# Altium schematic sheet StudentForce_DYNAMIC.SchDoc
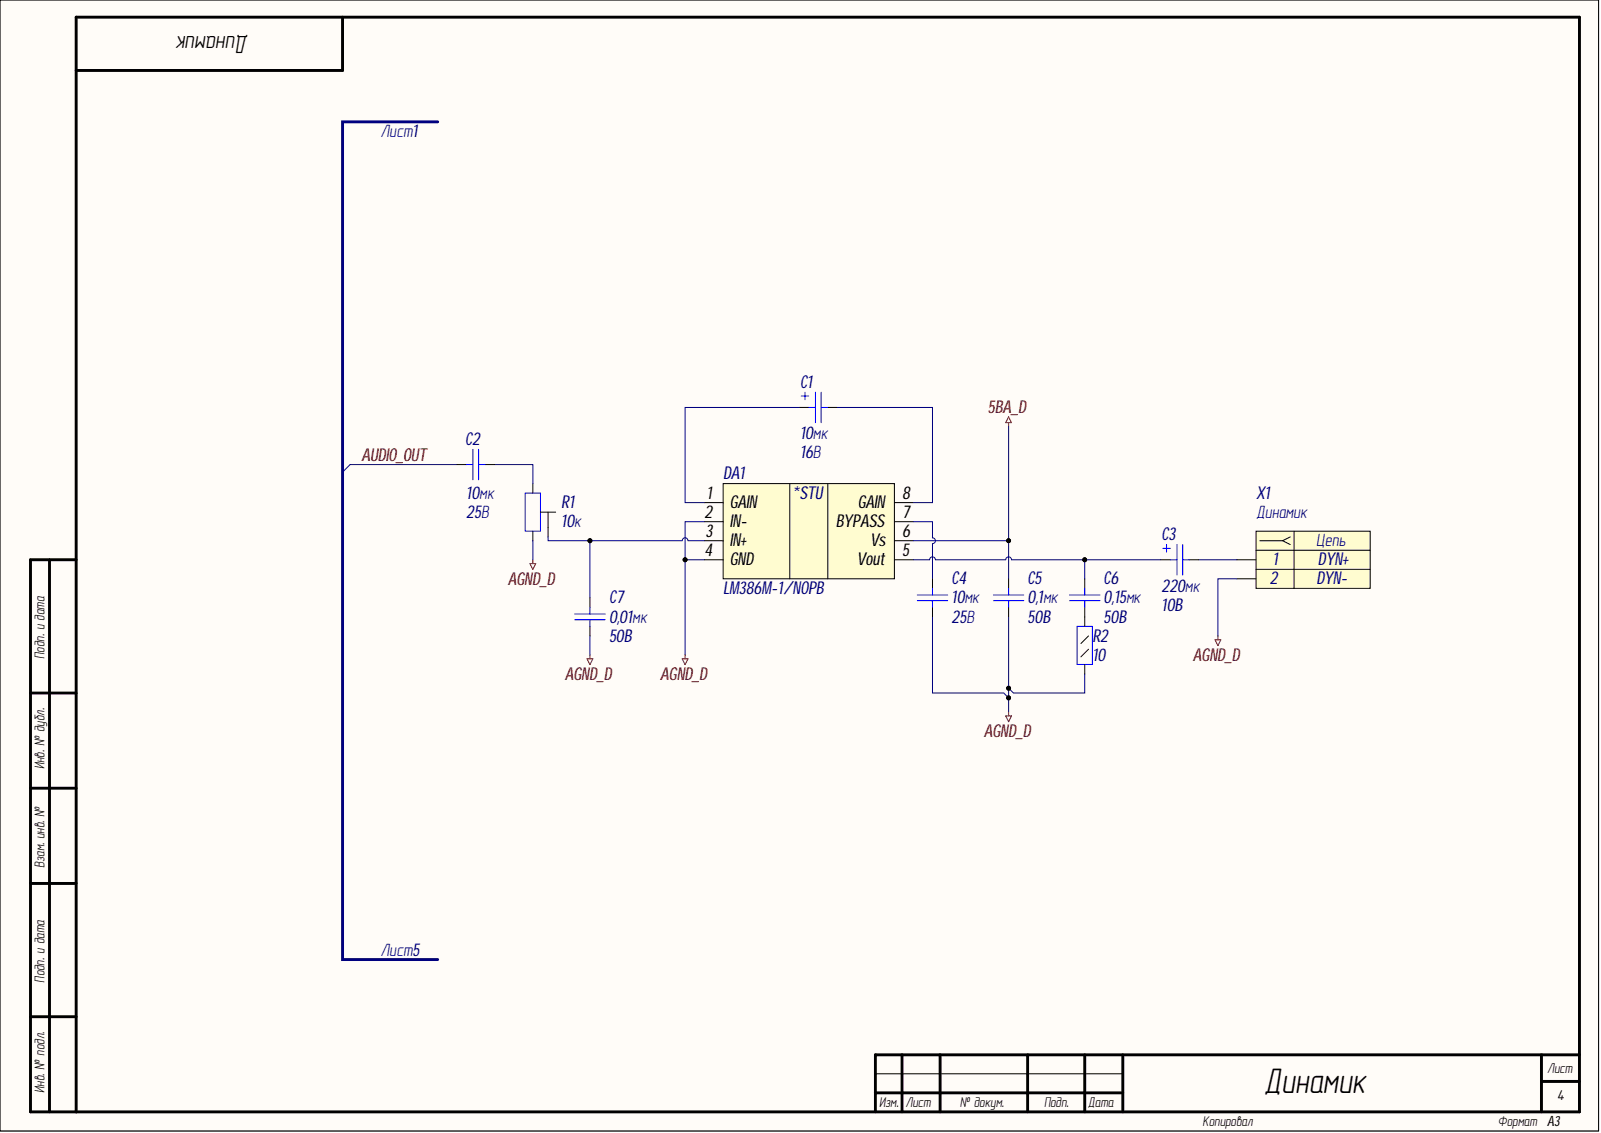
[diagram p0: StudentForce_DYNAMIC.SchDoc]

## Parts
C1  value 10мк  Voltage: 16В  [TANTAL CASE D]  (hidden comment: Capacitor_pol)
C2  value 10мк  [C1210]  (hidden comment: Capacitor)
C3  value 220мк  Voltage: 10B  [TANTAL CASE D]  (hidden comment: Capacitor_pol)
C4  value 10мк  Voltage: 25В  [C1206]  (hidden comment: Capacitor)
C5  value 0,1мк  Voltage: 50B  [C0805]  (hidden comment: Capacitor)
C6  value 0,15мк  Voltage: 50B  [C0805]  (hidden comment: Capacitor)
C7  value 0,01мк  Voltage: 50B  [C0805]  (hidden comment: Capacitor)
DA1  LM386M-1/NOPB  [SO8]
R1  10к  [Potentiometer]
R2  value 10  [R0805]  (hidden comment: Resistor_0,125Вт)
X1  Динамик  Contact_1: DYN+  Contact_2: DYN-  [CWF-2R]

## Nets
5BA_D: C5.2 DA1.6(Vs)
AGND_D: C4.1 C5.1 C7.1 DA1.2(IN-) DA1.4(GND) R1.3 R2.1 X1.2
AUDIO_OUT: C2.1
NetC1_1: C1.1 DA1.1(GAIN)
NetC1_2: C1.2 DA1.8(GAIN)
NetC2_2: C2.2 R1.1
NetC3_1: C3.1 C6.2 DA1.5(Vout)
NetC3_2: C3.2 X1.1
NetC4_2: C4.2 DA1.7(BYPASS)
NetC6_1: C6.1 R2.2
NetC7_2: C7.2 DA1.3(IN+) R1.2

## Library data of the parts
C1 = Polimer: Конденсатор полярный; Part Number: ECASD41C106M060K; Database Table Name: Capacitors$; TKE: 60mOhm; Component Kind: Standard; Library Name: MOP44.DbLib; Part Name: Polimer
C2 = cap193: Конденсатор; Manufacture: Murata; Part Name: Ceramic; Part Number: GRM32ER61A106K; Database Table Name: Capacitors$; TKE: X7R; Voltage: 25В; Component Kind: Standard; id: cap193; Library Name: MOP44.DbLib
C3 = cap192: Конденсатор полярный; Manufacture: KEMET; Part Name: Tantal; Part Number: T491D227K016ZT; Database Table Name: Capacitors$; Component Kind: Standard; id: cap192; Library Name: MOP44.DbLib
C4 = cap186: Конденсатор; Manufacture: Murata; Part Name: Ceramic; Part Number: GRM31CR71E106KA12L*; Database Table Name: Capacitors$; TKE: X7R; Component Kind: Standard; id: cap186; Library Name: MOP44.DbLib
C5 = cap141: Конденсатор; Manufacture: Murata; Part Name: Ceramic; Part Number: GRM21BR71H104K**; Database Table Name: Capacitors$; TKE: X7R; Component Kind: Standard; id: cap141; Library Name: MOP44.DbLib
C6 = cap142: Конденсатор; Manufacture: Murata; Part Name: Ceramic; Part Number: GRM21BR71H154K; Database Table Name: Capacitors$; TKE: X7R; Component Kind: Standard; id: cap142; Library Name: MOP44.DbLib
C7 = cap136: Конденсатор; Manufacture: Murata; Part Name: Ceramic; Part Number: GRM216R71H103K; Database Table Name: Capacitors$; TKE: X7R; Component Kind: Standard; id: cap136; Library Name: MOP44.DbLib
DA1 = LM386M-1/NOPB: Low Voltage Audio Power Amplifier; Bandwidth: 300кГц; Channels: 1; Database Table Name: Amplifier$; Component Kind: Standard; Library Name: MOP44.DbLib; Part Name: Audio Power Amplifier; Part Number: LM386M-1/NOPB
R1 = res1931: Potentiometer; Part Name: Trimming Potentiometer; Part Number: 3302X-3-103; Accuracy: 25%; Database Table Name: Resistor$; Power: 0,15Вт; Component Kind: Standard; id: res1931; Library Name: MOP44.DbLib; Value: 10к
R2 = res25: Резистор; Manufacture: Yageo; Part Number: RC0805FR-0710RL; Accuracy: 0.01; Database Table Name: Resistor$; Component Kind: Standard; id: res25; Library Name: MOP44.DbLib; Part Name: Resistor; Power: 0,125Вт
X1 = connect7: Разъем - 2 конт. розетка; Manufacture: Ningbo connfly electronic; Part Name: CWF-2R type A; Part Number: DS1069-2 MR-A; Database Table Name: Connector$; Etch: 2,5mm; Component Kind: Standard; id: connect7; Library Name: MOP44.DbLib
